# Altium schematic sheet Sheet1.SchDoc
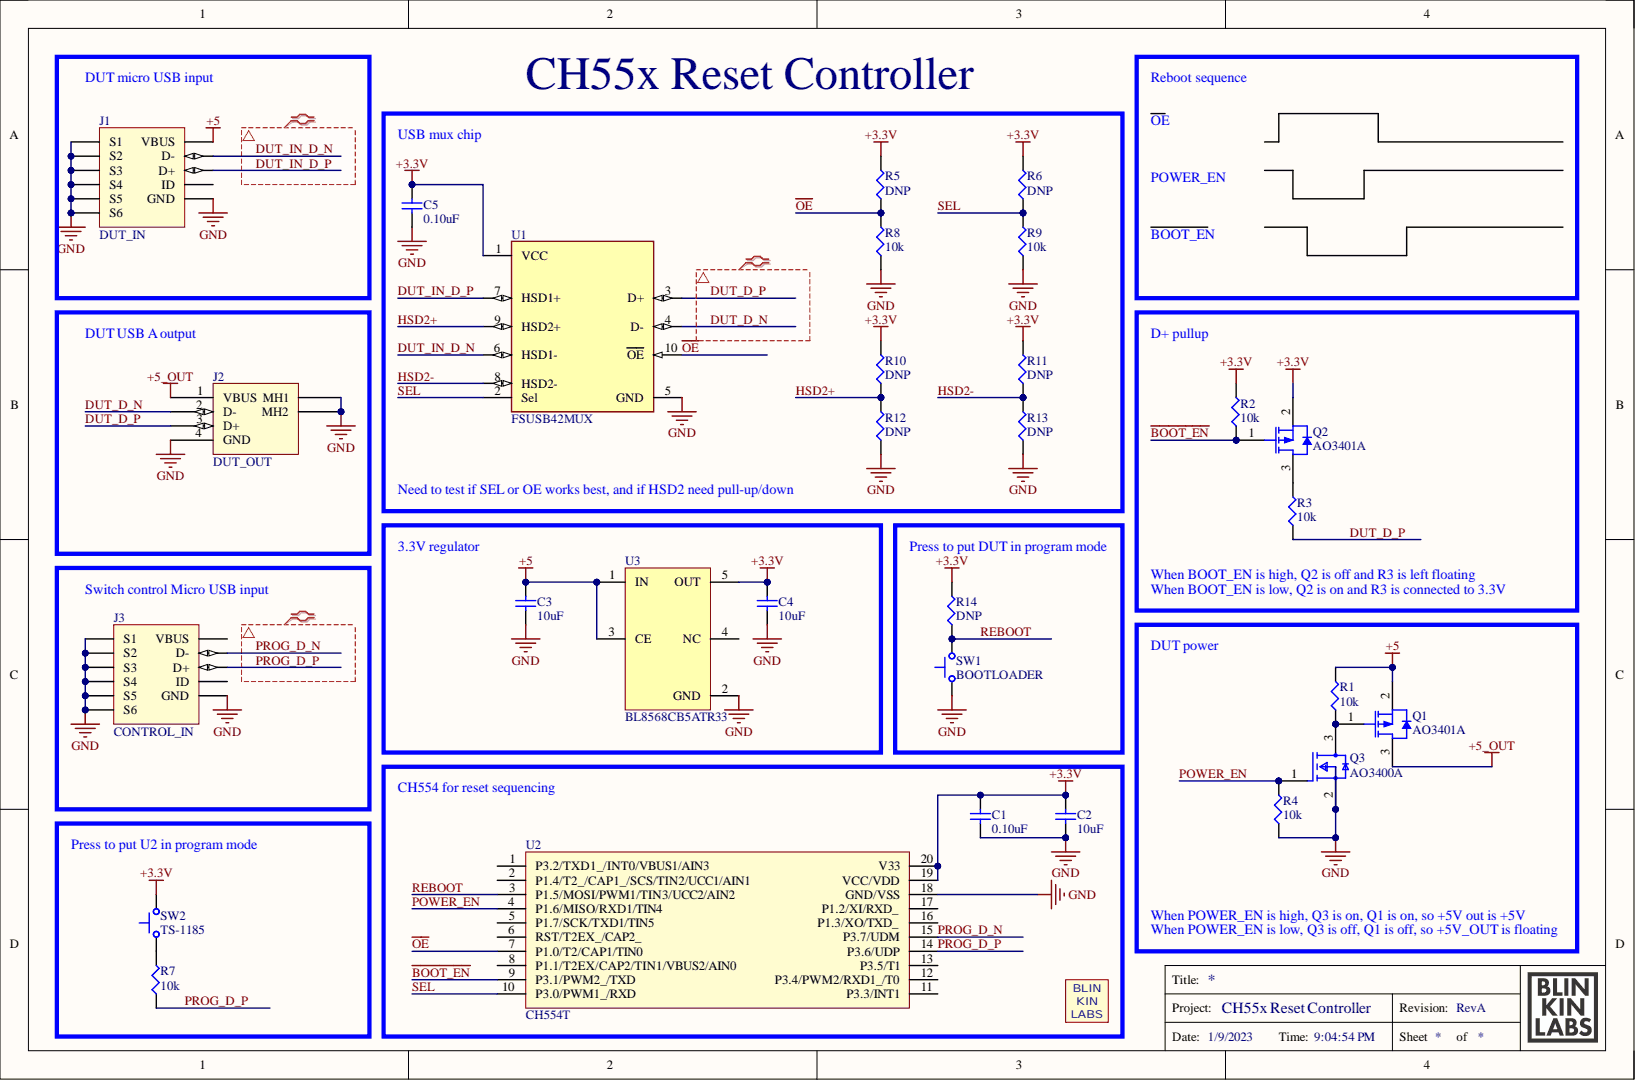
[diagram p0: Sheet1.SchDoc]

## Parts
C1  0.10uF  [CAPC1005X06L]
C2  10uF  [CAPC2012X10L]
C3  10uF  [CAPC2012X10L]
C4  10uF  [CAPC1608X09L]
C5  0.10uF  [CAPC1005X06L]
J1  DUT_IN  [A01SB741B1-267]
J2  DUT_OUT  [C42605]
J3  CONTROL_IN  [A01SB741B1-267]
L?  BLINKINLABS_LOGO  [BLINKINLABS_LOGO_SMALL]  (value not drawn)  (no BOM)
Q1  AO3401A  [AO-SOT-23-3L_V]
Q2  AO3401A  [AO-SOT-23-3L_V]
Q3  AO3400A  [FP-SOT23-MFG]
R1  10k  [ERJ2G(0402)_L]
R2  10k  [ERJ2G(0402)_L]
R3  10k  [ERJ2G(0402)_L]
R4  10k  [ERJ2G(0402)_L]
R5  DNP  [ERJ2G(0402)_L]
R6  DNP  [ERJ2G(0402)_L]
R7  10k  [ERJ2G(0402)_L]
R8  10k  [ERJ2G(0402)_L]
R9  10k  [ERJ2G(0402)_L]
R10  DNP  [ERJ2G(0402)_L]
R11  DNP  [ERJ2G(0402)_L]
R12  DNP  [ERJ2G(0402)_L]
R13  DNP  [ERJ2G(0402)_L]
R14  DNP  [ERJ2G(0402)_L]
SW1  BOOTLOADER  [TS-1185]
SW2  TS-1185  [TS-1185]
U1  FSUSB42MUX  [FP-846AP-MFG]
U2  CH554T  [TSOP65P660X110-20N]
U3  BL8568CB5ATR33  [SOT95P280X130-5N-2]

## Nets
+3.3V: C1.1 C2.1 C4.1 C5.1 Q2.2 R2.2 R5.2 R6.2 R10.2 R11.2 R14.2 SW2.2 U1.1(VCC) U2.19(VCC/VDD) U2.20(V33) U3.5(OUT)
+5: C3.1 J1.VBUS Q1.2 R1.2 U3.1(IN) U3.3(CE)
+5_OUT: J2.1(VBUS) Q1.3
GND: C1.2 C2.2 C3.2 C4.2 C5.2 J1.GND J1.S1 J1.S2 J1.S3 J1.S4 J1.S5 J1.S6 J2.4(GND) J2.5(MH2) J2.6(MH1) J3.GND J3.S1 J3.S2 J3.S3 J3.S4 J3.S5 J3.S6 Q3.2 R4.1 R8.1 R9.1 R12.1 R13.1 SW1.1 U1.5(GND) U2.18(GND/VSS) U3.2(GND)
~{BOOT_EN}: Q2.1 R2.1 U2.9(P3.1/PWM2_/TXD)
DUT_D_N: J2.2(D-) U1.4(D-)
DUT_D_P: J2.3(D+) R3.1 U1.3(D+)
DUT_IN_D_N: J1.D- U1.6(HSD1-)
DUT_IN_D_P: J1.D+ U1.7(HSD1+)
HSD2+: R10.1 R12.2 U1.9(HSD2+)
HSD2-: R11.1 R13.2 U1.8(HSD2-)
~{OE}: R5.1 R8.2 U1.10(OE) U2.7(P1.0/T2/CAP1/TIN0)
POWER_EN: Q3.1 R4.2 U2.4(P1.6/MISO/RXD1/TIN4)
PROG_D_N: J3.D- U2.15(P3.7/UDM)
PROG_D_P: J3.D+ R7.1 U2.14(P3.6/UDP)
REBOOT: R14.1 SW1.2 U2.3(P1.5/MOSI/PWM1/TIN3/UCC2/AIN2)
SEL: R6.1 R9.2 U1.2(Sel) U2.10(P3.0/PWM1_/RXD)
NetQ1_1: Q1.1 Q3.3 R1.1
NetQ2_3: Q2.3 R3.2
NetR7_2: R7.2 SW2.1

Not connected: J1 ID; J3 ID VBUS; U2 1(P3.2/TXD1_/INT0/VBUS1/AIN3) 2(P1.4/T2_/CAP1_/SCS/TIN2/UCC1/AIN1) 5(P1.7/SCK/TXD1/TIN5) 6(RST/T2EX_/CAP2_) 8(P1.1/T2EX/CAP2/TIN1/VBUS2/AIN0) 11(P3.3/INT1) 12(P3.4/PWM2/RXD1_/T0) 13(P3.5/T1) 16(P1.3/XO/TXD_) 17(P1.2/XI/RXD_); U3 4(NC)

## Library data of the parts
C1 C5 = GRM155R71C104KA88J: CAP CER 0.1UF 16V X7R 0402; Manufacturer: Murata Electronics North America; Database Table Name: capacitor_murata_0402$; Tolerance: ±10%; Applications: General Purpose; Case/Package: 0402; Component Kind: Standard; Datasheets: http://www.murata.com/~/media/webrenewal/support/library/catalog/products/capacitor/mlcc/c02e.pdf; Digi-Key Part Number: 490-6328-1-ND; Features: -; Image: //media.digikey.com/Renders/Murata%20Renders/ZRB-SERIES-0402.jpg; Library Name: cap_murata.DbLib; Operating Temperature: -55°C ~ 125°C; Package / Case: 0402 (1005 Metric); Packaging: Cut Tape (CT); PartNumber: GRM155R71C104KA88J; Series: GRM; Temperature Coefficient: X7R; Value: 0.10uF; Voltage: 16V
C2 = GRM21BR71A106KE51K: CAP CER 10UF 10V X7R 0805; Manufacturer: Murata Electronics North America; Database Table Name: capacitor_murata_0805$; Tolerance: ±10%; Applications: General Purpose; Case/Package: 0805; Component Kind: Standard; Datasheets: http://www.murata.com/~/media/webrenewal/support/library/catalog/products/capacitor/mlcc/c02e.pdf; Digi-Key Part Number: 490-6477-1-ND; Features: -; Image: //media.digikey.com/Renders/Murata%20Renders/0805%20(2012%20Metric)%20.85mm.jpg; Library Name: cap_murata.DbLib; Mounting Type: Surface Mount, MLCC; Operating Temperature: -55°C ~ 125°C; Package / Case: 0805 (2012 Metric); Part Status: Active; PartNumber: GRM21BR71A106KE51K; Series: GRM; Temperature Coefficient: X7R; Value: 10uF; Voltage: 10V
C3 = GRM21BR61C106ME15L: CAP CER 10UF 16V X5R 0805; Manufacturer: Murata Electronics North America; Database Table Name: capacitor_murata_0805$; Tolerance: ±20%; Applications: General Purpose; Case/Package: 0805; Component Kind: Standard; Datasheets: http://www.murata.com/~/media/webrenewal/support/library/catalog/products/capacitor/mlcc/c02e.pdf; Digi-Key Part Number: 490-6474-1-ND; Features: -; Image: //media.digikey.com/Renders/Murata%20Renders/0805%20(2012%20Metric)%20.85mm.jpg; Library Name: cap_murata.DbLib; Mounting Type: Surface Mount, MLCC; Operating Temperature: -55°C ~ 85°C; Package / Case: 0805 (2012 Metric); Part Status: Active; PartNumber: GRM21BR61C106ME15L; Series: GRM; Temperature Coefficient: X5R; Value: 10uF; Voltage: 16V
C4 = GRM188R61A106ME69D: CAP CER 10UF 10V X5R 0603; Manufacturer: Murata Electronics North America; Database Table Name: capacitor_murata_0603$; Tolerance: ±20%; Applications: General Purpose; Case/Package: 0603; Component Kind: Standard; Datasheets: http://www.murata.com/~/media/webrenewal/support/library/catalog/products/capacitor/mlcc/c02e.pdf; Digi-Key Part Number: 490-10475-1-ND; Features: Derating Recommended; Image: //media.digikey.com/Renders/Murata%20Renders/ZRB-SERIES-0402.jpg; Library Name: cap_murata.DbLib; Operating Temperature: -55°C ~ 85°C; Package / Case: 0603 (1608 Metric); PartNumber: GRM188R61A106ME69D; Series: GRM; Temperature Coefficient: X5R; Value: 10uF; Voltage: 10V
J1 J3 = A01SB741B1-267: Micro USB B type 四脚全插牛角; Manufacturer: Unknown/Generic; Component Kind: Standard; PartNumber: Unknown/Generic; Library Name: Blinkinlabs.IntLib
J2 = C42605: USB A connector, SMD, no tabs; Manufacturer: LC (立创); Component Kind: Standard; PartNumber: C42605; Library Name: Blinkinlabs.IntLib
L? = BLINKINLABS_LOGO: Square logo; Library Name: Blinkinlabs.IntLib
Q1 Q2 = CMP-2000-05778-1: P-Channel MOSFET, 30 V, 4 A, -55 to 150 degC, 3-Pin SOT-23-3L, RoHS, Tape and Reel; Manufacturer: Alpha and Omega; Channel Mode: Enhancement; Continuous Drain Current: 4A; Drain Efficiency: NotRequired%; Drain-Source On-Res: 0.044ohm; Drain-Source On-Volt: 30V; Frequency (Max): NotRequiredMHz; Gate-Source Voltage (Max): ?12V; Noise Figure: NotRequireddB; Number of  Elements: 1; Output Power (Max): NotRequiredW; Polarity: P; Power Dissipation: 1.4W; Rad Hardened: No; Type: PowerMOSFET; Component Kind: Standard; Datasheet URL: http://www.aosmd.com/res/data_sheets/AO3401A.pdf; Datasheet Version: Rev. 3, 03/2011; Drain Current (Max): 4A; Library Name: Altium Content Vault; Manufacturer URL: http://www.aosmd.com/; Mounting: SurfaceMount; Mounting Technology: SurfaceMount; Operating Temp Range: -55Cto150C; Operating Temperature Classification: Military; Package Description: 3-Pin Small Outline Transistor, Body 2.95 x 1.6 mm, Pitch 0.95 mm; Package Reference: SOT-23-3L; Package Type: SOT-23; Package URL: http://www.aosmd.com/res/packaging_information/SOT-23-3L.pdf; Pin Count: 3; Power Gain: NotRequireddB
Q3 = CMP-83955-000006-1: MOSFET N-CH 30V 5.7A SOT23; Gate to Source Voltage (Vgs): 12V; Input Capacitance: 630pF; Max Power Dissipation: 1.4W; Number of Elements: 1; Rds On Max: 26.5mR; Case/Package: SOT-23; Component Kind: Standard; Continuous Drain Current (ID): 5.7A; Drain to Source Voltage (Vdss): 30V; Lead Free: Lead Free; Library Name: Altium Content Vault; Max Operating Temperature: 150°C; Min Operating Temperature: -55°C; Mount: Surface Mount; Number of Pins: 3; Packaging: Tape and Reel; Power Dissipation: 1.4W; Radiation Hardening: No
R1-R4 R7-R9 = ERJ-2GEJ103X: RES SMD 10K OHM 5% 1/10W 0402; Manufacturer: Panasonic Electronic Components; Database Table Name: Panasonic_ERJ_0402; Power (Watts): 0.1W, 1/10W; Temperature Coefficient: ±200ppm/°C; Case/Package: 0402; Component Kind: Standard; Composition: Thick Film; Datasheets: http://industrial.panasonic.com/www-cgi/jvcr13pz.cgi?E+PZ+3+AOA0001+ERJ2GEJ103X+7+WW; Digi-Key Part Number: P10KJCT-ND; Features: Automotive AEC-Q200; Height - Seated (Max): 0.016" (0.40mm); Image: //media.digikey.com/Photos/Panasonic%20Photos/ERJ-PA2-Series.jpg; Number of Terminations: 2; Operating Temperature: -55°C ~ 155°C; Package / Case: 0402 (1005 Metric); PartNumber: ERJ-2GEJ103X; Series: ERJ; Size / Dimension: 0.039" L x 0.020" W (1.00mm x 0.50mm); Tolerance: ±5%; Value: 10k; Library Name: res_panasonic_erj_xls.DbLib
R5 R6 R10-R14 = DNP: Database Table Name: Panasonic_ERJ_0402; Case/Package: 0402; Component Kind: Standard; Package / Case: 0402 (1005 Metric); PartNumber: DNP; Value: DNP; Library Name: res_panasonic_erj_xls.DbLib
SW1 SW2 = TS-1185: Tact Switch, 3x4mm, no alignment pin, turtle style; Manufacturer: XKB; Component Kind: Standard; PartNumber: TS-1185; Library Name: Blinkinlabs.IntLib
U1 = CMP-07103-000004-1: IC USB SWITCH DPDT 10MSOP; Current: 100mA; Data Rate: 480Mbps; Max Supply Voltage: 4.3V; Min Supply Voltage: 3V; Number of Channels: 2; Number of Outputs: 4; On-State Resistance: 6.5R; Supply Type: Single; Case/Package: MSOP; Component Kind: Standard; Height: 0.85mm; Lead Free: Lead Free; Length: 3mm; Library Name: Altium Content Vault; Max Operating Temperature: 85°C; Min Operating Temperature: -40°C; Mount: Surface Mount; Number of Inputs: 2; Number of Pins: 10; Operating Supply Voltage: 4.3V; Package Quantity: 1; Packaging: Tape and Reel; Radiation Hardening: No; REACH SVHC: No SVHC; Throw Configuration: DPDT; Voltage: 4.3V; Weight: 0.1125g; Width: 3mm
U2 = CH554T: 8-bit enhanced USB microcontroller; Manufacturer: WCH; Component Kind: Standard; PartNumber: CH554T; Library Name: Blinkinlabs.IntLib
U3 = BL8568CB5ATR33: 500mA High PSRR, Linear Regulator, w. Output Discharge; Manufacturer: Shanghai Belling; Component Kind: Standard; LCSC PartNumber: C243122; PartNumber: BL8568CB5ATR33; Library Name: Blinkinlabs.IntLib
